# Altium schematic sheet MCU.SchDoc(MCU)
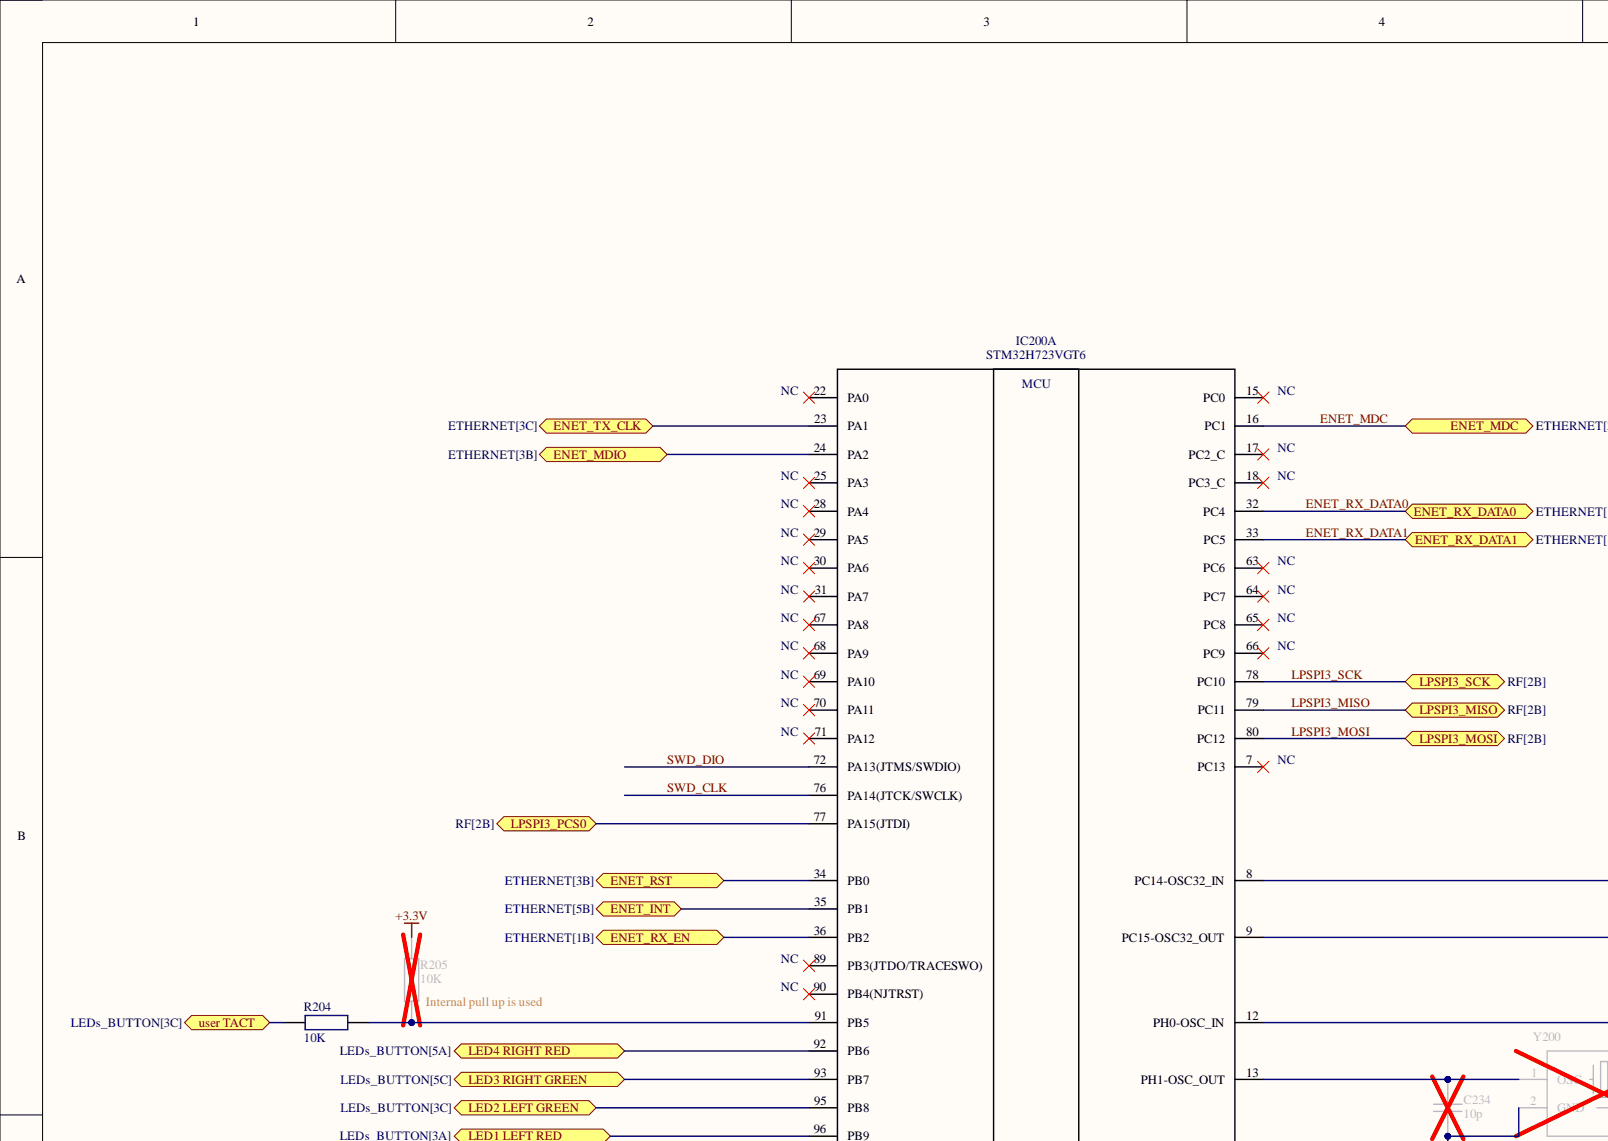
[diagram p0: MCU.SchDoc, top left]
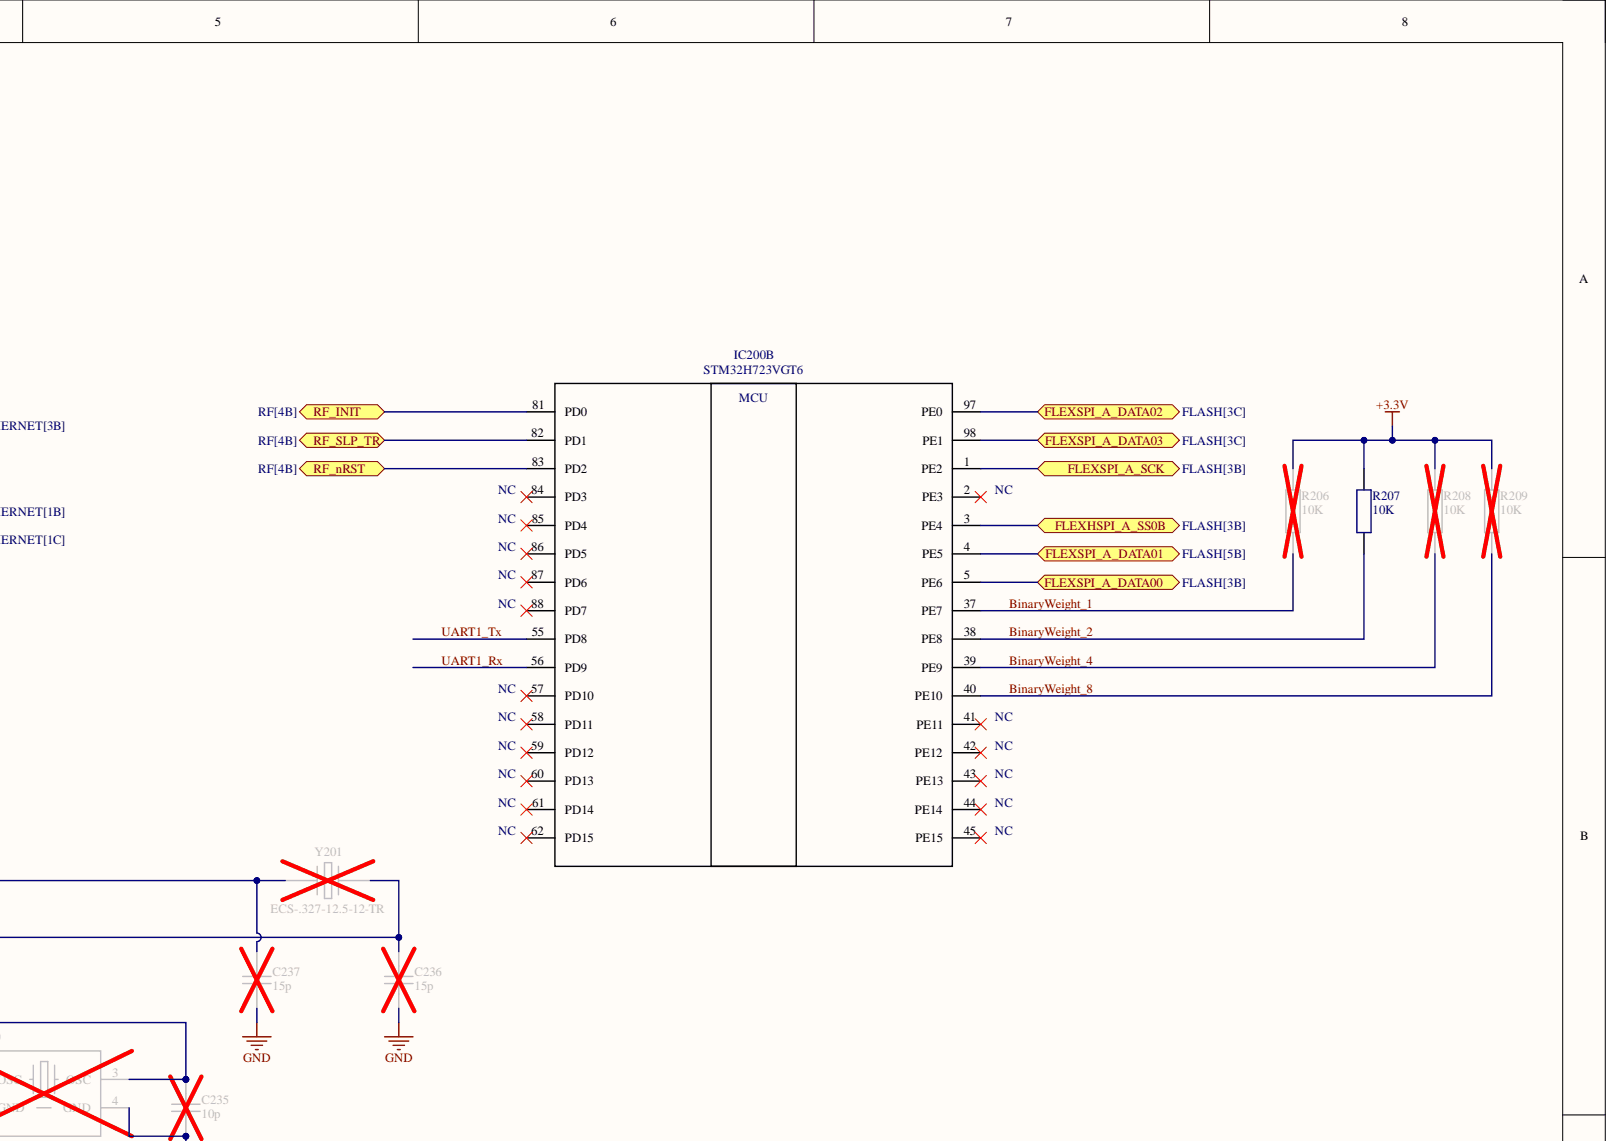
[diagram p1: MCU.SchDoc, top right]
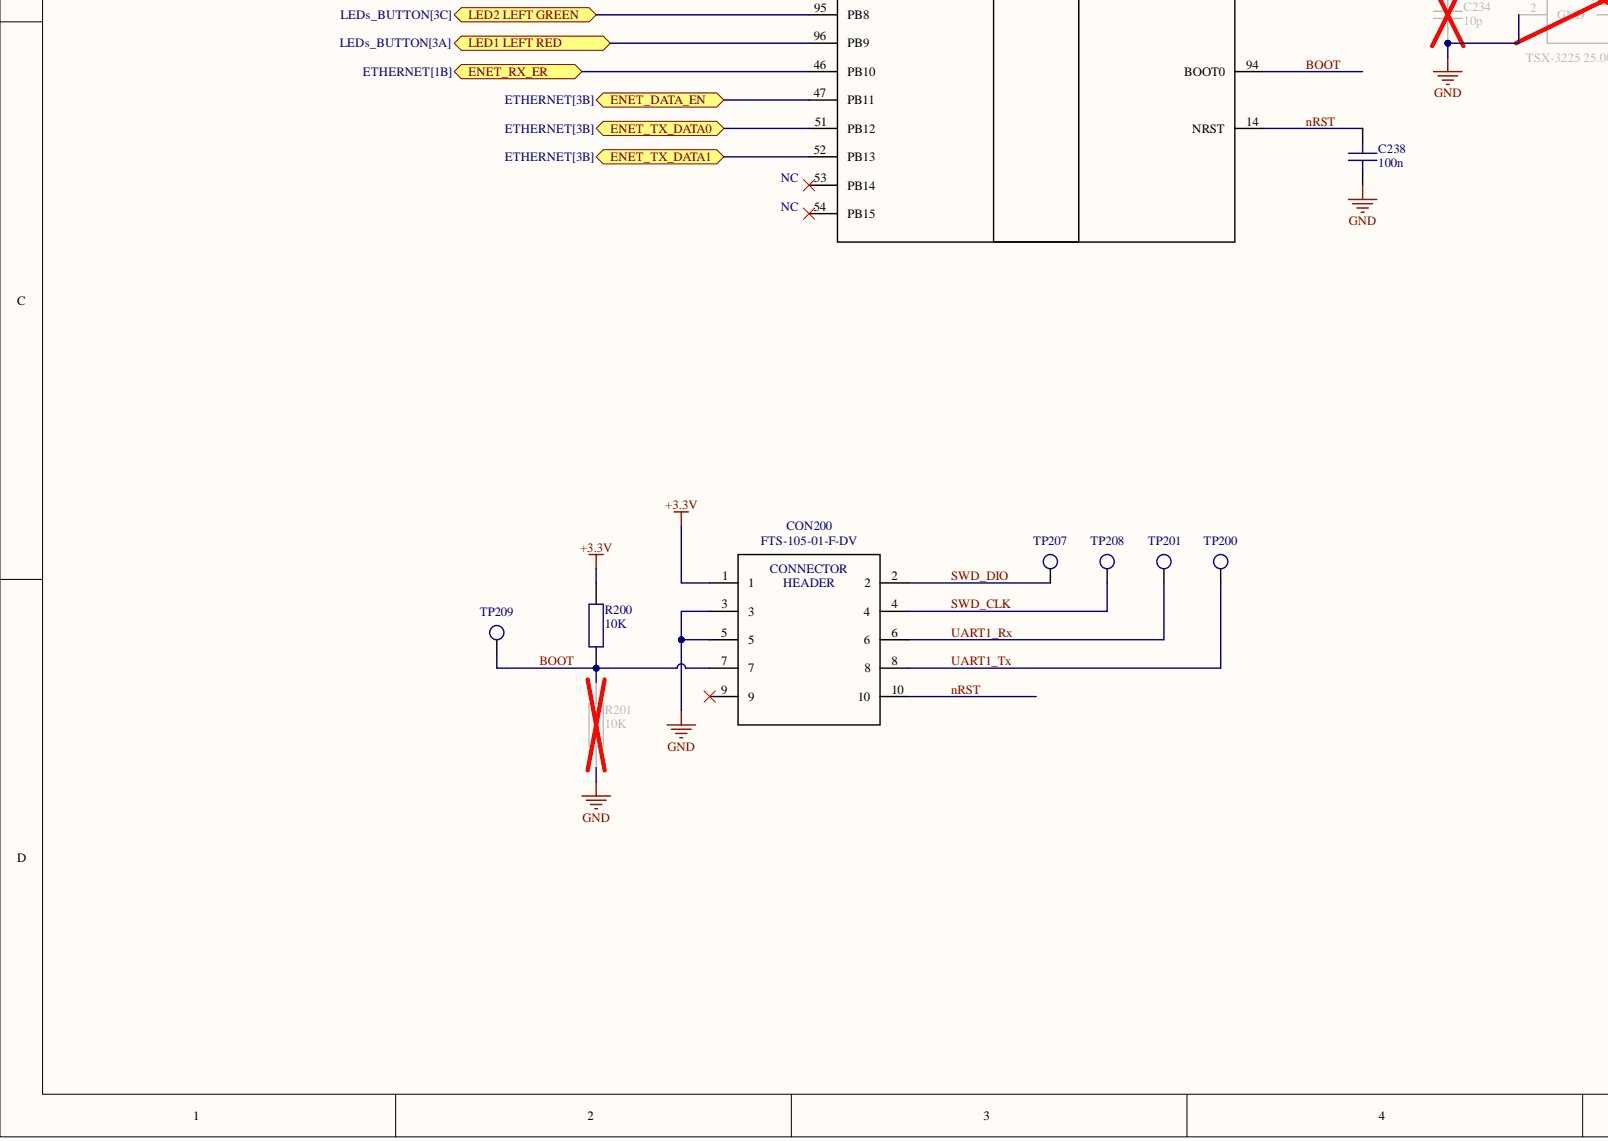
[diagram p2: MCU.SchDoc, bottom left]
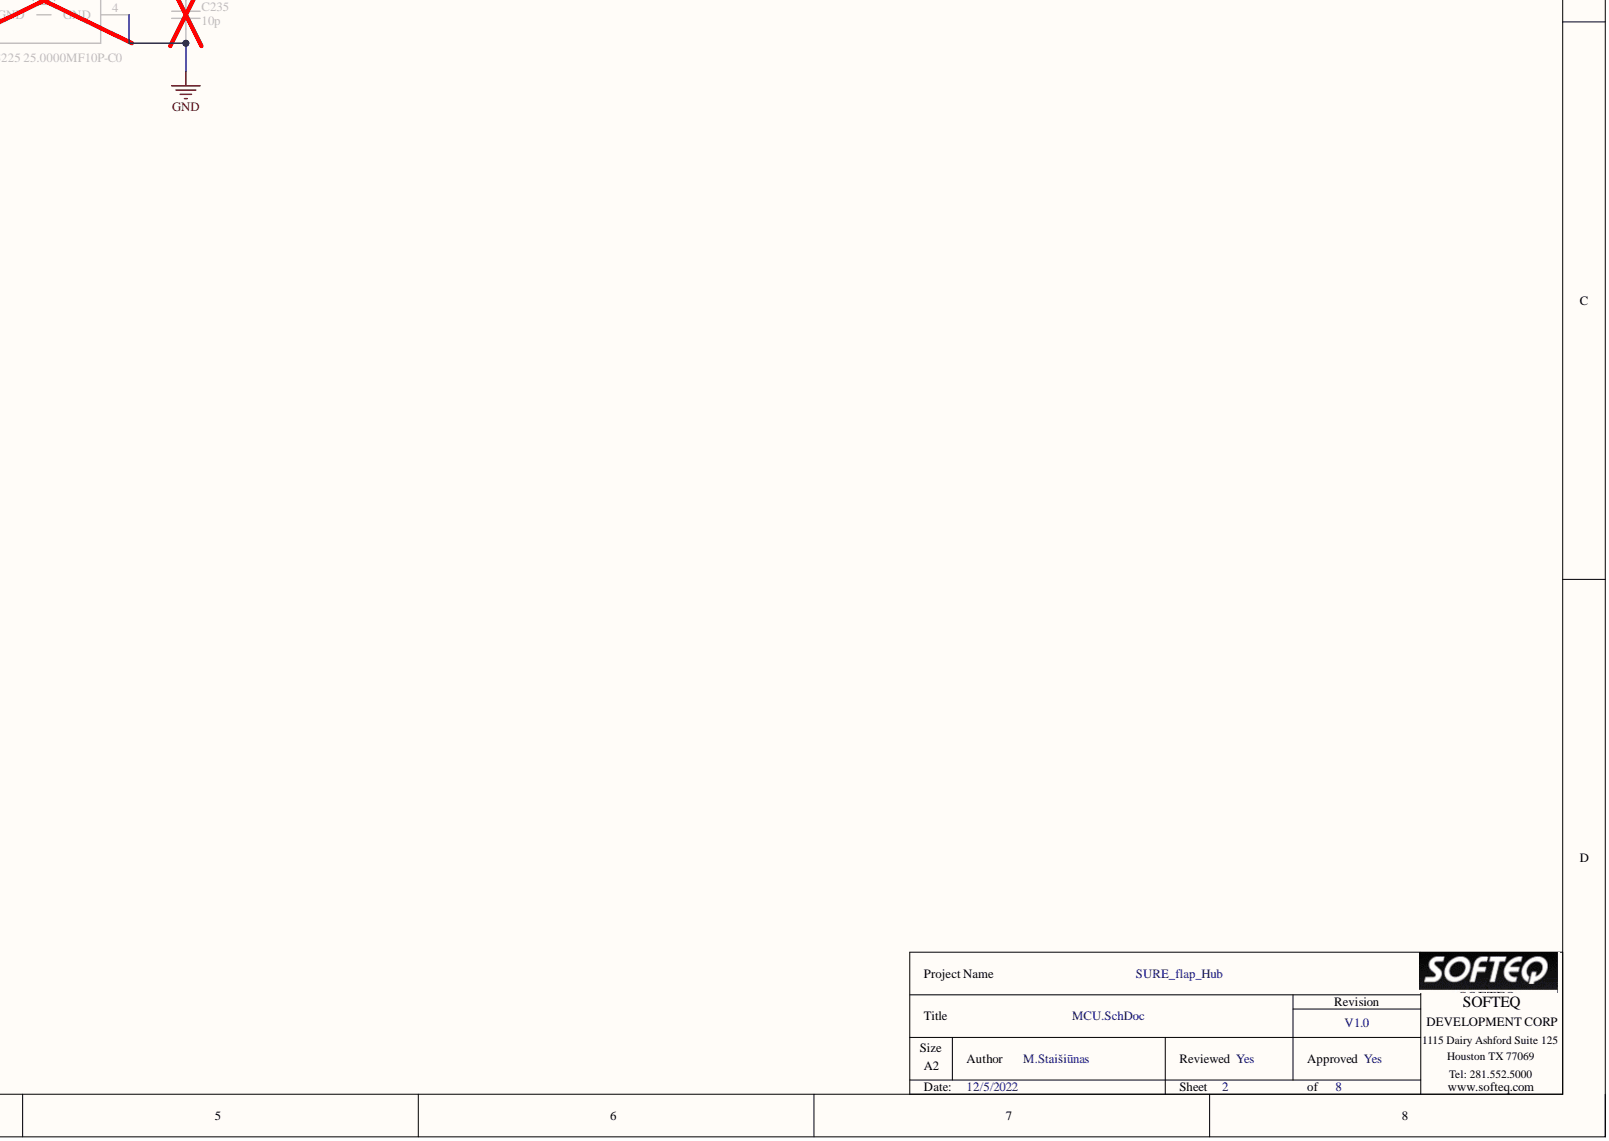
[diagram p3: MCU.SchDoc, bottom right]

## Parts
C234  value 10p  [C_0402]  (hidden comment: CC0402JRNPO9BN100)
C235  value 10p  [C_0402]  (hidden comment: CC0402JRNPO9BN100)
C236  value 15p  [C_0402]  (hidden comment: CC0402JRNPO9BN150)
C237  value 15p  [C_0402]  (hidden comment: CC0402JRNPO9BN150)
C238  value 100n  [C_0402]  (hidden comment: CL05B104KO5NNNC)
CON200  FTS-105-01-F-DV  [SAMTEC_FTS-105-01-X-DV]
IC200  STM32H723VGT6  [QFP-100[25x25]_0.30_0.50_14X14X1.6]  (parts A,B)
R200  value 10K  [R_0402]  (hidden comment: RC0402FR-0710KL)
R201  value 10K  [R_0402]  (hidden comment: RC0402FR-0710KL)
R204  value 10K  [R_0402]  (hidden comment: RC0402FR-0710KL)
R205  value 10K  [R_0402]  (hidden comment: RC0402FR-0710KL)
R206  value 10K  [R_0402]  (hidden comment: RC0402FR-0710KL)
R207  value 10K  [R_0402]  (hidden comment: RC0402FR-0710KL)
R208  value 10K  [R_0402]  (hidden comment: RC0402FR-0710KL)
R209  value 10K  [R_0402]  (hidden comment: RC0402FR-0710KL)
TP200  SolderPoint_2.0  [SSP_2.0]  (value not drawn)  (no BOM)
TP201  SolderPoint_2.0  [SSP_2.0]  (value not drawn)  (no BOM)
TP207  (no value)  [SSP_1.2]  (no BOM)
TP208  (no value)  [SSP_1.2]  (no BOM)
TP209  (no value)  [SSP_1.2]  (no BOM)
Y200  TSX-3225 25.0000MF10P-C0  [EPSON_TSX-3225]
Y201  ECS-.327-12.5-12-TR  [ECS_ECX-12]

## Ports
ENET_DATA_EN, ENET_INT, ENET_MDC, ENET_MDIO, ENET_RST, ENET_RX_DATA0, ENET_RX_DATA1, ENET_RX_EN, ENET_RX_ER, ENET_TX_CLK, ENET_TX_DATA0, ENET_TX_DATA1, FLEXHSPI_A_SS0B, FLEXSPI_A_DATA00, FLEXSPI_A_DATA01, FLEXSPI_A_DATA02, FLEXSPI_A_DATA03, FLEXSPI_A_SCK, LED1 LEFT RED, LED2 LEFT GREEN, LED3 RIGHT GREEN, LED4 RIGHT RED, LPSPI3_MISO, LPSPI3_MOSI, LPSPI3_PCS0, LPSPI3_SCK, RF_INIT, RF_nRST, RF_SLP_TR, user TACT

## Nets
+3.3V: CON200.1 R200.2 R205.1 R206.2 R207.2 R208.2 R209.2
GND: C234.1 C235.1 C236.1 C237.1 C238.1 CON200.3 CON200.5 R201.1 Y200.2(GND) Y200.4(GND)
BinaryWeight_1: IC200.37(PE7) R206.1
BinaryWeight_2: IC200.38(PE8) R207.1
BinaryWeight_4: IC200.39(PE9) R208.1
BinaryWeight_8: IC200.40(PE10) R209.1
BOOT: CON200.7 IC200.94(BOOT0) R200.1 R201.2 TP209.1
ENET_DATA_EN: IC200.47(PB11) ; port ENET_DATA_EN
ENET_INT: IC200.35(PB1) ; port ENET_INT
ENET_MDC: IC200.16(PC1) ; port ENET_MDC
ENET_MDIO: IC200.24(PA2) ; port ENET_MDIO
ENET_RST: IC200.34(PB0) ; port ENET_RST
ENET_RX_DATA0: IC200.32(PC4) ; port ENET_RX_DATA0
ENET_RX_DATA1: IC200.33(PC5) ; port ENET_RX_DATA1
ENET_RX_EN: IC200.36(PB2) ; port ENET_RX_EN
ENET_RX_ER: IC200.46(PB10) ; port ENET_RX_ER
ENET_TX_CLK: IC200.23(PA1) ; port ENET_TX_CLK
ENET_TX_DATA0: IC200.51(PB12) ; port ENET_TX_DATA0
ENET_TX_DATA1: IC200.52(PB13) ; port ENET_TX_DATA1
FLEXHSPI_A_SS0B: IC200.3(PE4) ; port FLEXHSPI_A_SS0B
FLEXSPI_A_DATA00: IC200.5(PE6) ; port FLEXSPI_A_DATA00
FLEXSPI_A_DATA01: IC200.4(PE5) ; port FLEXSPI_A_DATA01
FLEXSPI_A_DATA02: IC200.97(PE0) ; port FLEXSPI_A_DATA02
FLEXSPI_A_DATA03: IC200.98(PE1) ; port FLEXSPI_A_DATA03
FLEXSPI_A_SCK: IC200.1(PE2) ; port FLEXSPI_A_SCK
LED1 LEFT RED: IC200.96(PB9) ; port LED1 LEFT RED
LED2 LEFT GREEN: IC200.95(PB8) ; port LED2 LEFT GREEN
LED3 RIGHT GREEN: IC200.93(PB7) ; port LED3 RIGHT GREEN
LED4 RIGHT RED: IC200.92(PB6) ; port LED4 RIGHT RED
LPSPI3_MISO: IC200.79(PC11) ; port LPSPI3_MISO
LPSPI3_MOSI: IC200.80(PC12) ; port LPSPI3_MOSI
LPSPI3_PCS0: IC200.77(PA15(JTDI)) ; port LPSPI3_PCS0
LPSPI3_SCK: IC200.78(PC10) ; port LPSPI3_SCK
nRST: C238.2 CON200.10 IC200.14(NRST)
RF_INIT: IC200.81(PD0) ; port RF_INIT
RF_nRST: IC200.83(PD2) ; port RF_nRST
RF_SLP_TR: IC200.82(PD1) ; port RF_SLP_TR
SWD_CLK: CON200.4 IC200.76(PA14(JTCK/SWCLK)) TP208.1
SWD_DIO: CON200.2 IC200.72(PA13(JTMS/SWDIO)) TP207.1
UART1_Rx: CON200.6 IC200.56(PD9) TP201.1
UART1_Tx: CON200.8 IC200.55(PD8) TP200.1
user TACT: R204.2 ; port user TACT
NetC234_2: C234.2 IC200.13(PH1-OSC_OUT) Y200.1(OSC)
NetC235_2: C235.2 IC200.12(PH0-OSC_IN) Y200.3(OSC)
NetC236_2: C236.2 IC200.9(PC15-OSC32_OUT) Y201.2
NetC237_2: C237.2 IC200.8(PC14-OSC32_IN) Y201.1
NetIC200_91: IC200.91(PB5) R204.1 R205.2

Not connected: CON200 9; IC200 2(PE3) 7(PC13) 15(PC0) 17(PC2_C) 18(PC3_C) 22(PA0) 25(PA3) 28(PA4) 29(PA5) 30(PA6) 31(PA7) 41(PE11) 42(PE12) 43(PE13) 44(PE14) 45(PE15) 53(PB14) 54(PB15) 57(PD10) 58(PD11) 59(PD12) 60(PD13) 61(PD14) 62(PD15) 63(PC6) 64(PC7) 65(PC8) 66(PC9) 67(PA8) 68(PA9) 69(PA10) 70(PA11) 71(PA12) 84(PD3) 85(PD4) 86(PD5) 87(PD6) 88(PD7) 89(PB3(JTDO/TRACESWO)) 90(PB4(NJTRST))

## Library data of the parts
C234 C235 = CC0402JRNPO9BN100: Capacitor, 10pF, 50V, NP0, 5%, -55/+125, 0402; Manufacturer: Yageo; Database Table Name: Capacitors; Component Kind: Standard; ComponentHeight: 0.5mm; Library Name: Library.dblib; Mounted: Yes; PartNumber: CC0402JRNPO9BN100; SMD: Yes; Author: KAI
C236 C237 = CC0402JRNPO9BN150: Capacitor, 15pF, 50V, NP0, 5%, -55/+125, 0402; Manufacturer: Yageo; Database Table Name: Capacitors; Component Kind: Standard; ComponentHeight: 0.5mm; Library Name: Library.dblib; Mounted: Yes; PartNumber: CC0402JRNPO9BN150; SMD: Yes; Author: KAI
C238 = CL05B104KO5NNNC: Capacitor, 100nF, 16V, X7R, 10%, -55/+125, 0402; Manufacturer: Samsung; Database Table Name: Capacitors; Component Kind: Standard; ComponentHeight: 0.55mm; Library Name: Library.dblib; Mounted: Yes; PartNumber: CL05B104KO5NNNC; SMD: Yes; Socket: No; Author: KAI
CON200 = FTS-105-01-F-DV: Conn, Header, 2x5POS, P-1.27mm, -55/+125, SMD; Manufacturer: Samtec; Database Table Name: Connectors; Component Kind: Standard; ComponentHeight: 4.45; Library Name: Library.dblib; Mounted: Yes; PartNumber: FTS-105-01-F-DV; SMD: Yes; Author: DZ
IC200 = STM32H723VGT6: IC, MCU, 32-bit, Single Core, ARM Cortex-M7, 550MHz, 1MB Flash, -40/+85, LQFP-100; Manufacturer: ST; Database Table Name: IC Digital; Component Kind: Standard; ComponentHeight: 1.6mm; Library Name: Library.dblib; Mounted: Yes; PartNumber: STM32H723VGT6; SMD: Yes; Author: DZ
R200 R201 R204-R209 = RC0402FR-0710KL: Resistor, 10K, 1%, 0.063W, -55/+155, 0402; Manufacturer: Yageo; Database Table Name: Resistors; Component Kind: Standard; ComponentHeight: 0.4mm; Mounted: Yes; PartNumber: RC0402FR-0710KL; SMD: Yes; Author: KAI
  R200 R201 R204 R205: Library Name: Library.DbLib
  R206-R209: Library Name: Library.dblib
TP200 TP201 = SolderPoint_SMD_2.0: Solder Point, 2.0mm, SMD; Database Table Name: Connectors; ComponentHeight: 0mm; Library Name: Library.dblib; Mounted: Yes; PartNumber: SolderPoint_SMD_2.0; SMD: Yes; Author: KAI
TP207-TP209 = SolderPoint_SMD_1.2: Solder Point, 1.2mm, SMD; Database Table Name: Connectors; ComponentHeight: 0mm; Library Name: Library.dblib; Mounted: Yes; PartNumber: SolderPoint_SMD_1.2; SMD: Yes; Author: KAI
Y200 = TSX-3225 25.0000MF10P-C0: Crystal, 25MHz, 10ppm, 10pF, -20/+85, 3.20x2.50mm, SMD; Manufacturer: Epson; Database Table Name: Resonators_Generator; Component Kind: Standard; ComponentHeight: 0.6mm; Library Name: Library.dblib; Mounted: Yes; PartNumber: TSX-3225 25.0000MF10P-C0; SMD: Yes; Value: 25MHz; Author: KMA
Y201 = ECS-.327-12.5-12-TR: Crystal, 32.768kHz, ±20ppm, 12.5pF, 2.0x 1.20mm,  -40/+85; Manufacturer: ECS; Database Table Name: Resonators_Generator; Component Kind: Standard; ComponentHeight: 0.6mm; Library Name: Library.dblib; Mounted: Yes; PartNumber: ECS-.327-12.5-12-TR; SMD: Yes; Value: 32.768kHz; Author: KAI
